# Altium schematic sheet tegprint.SchDoc
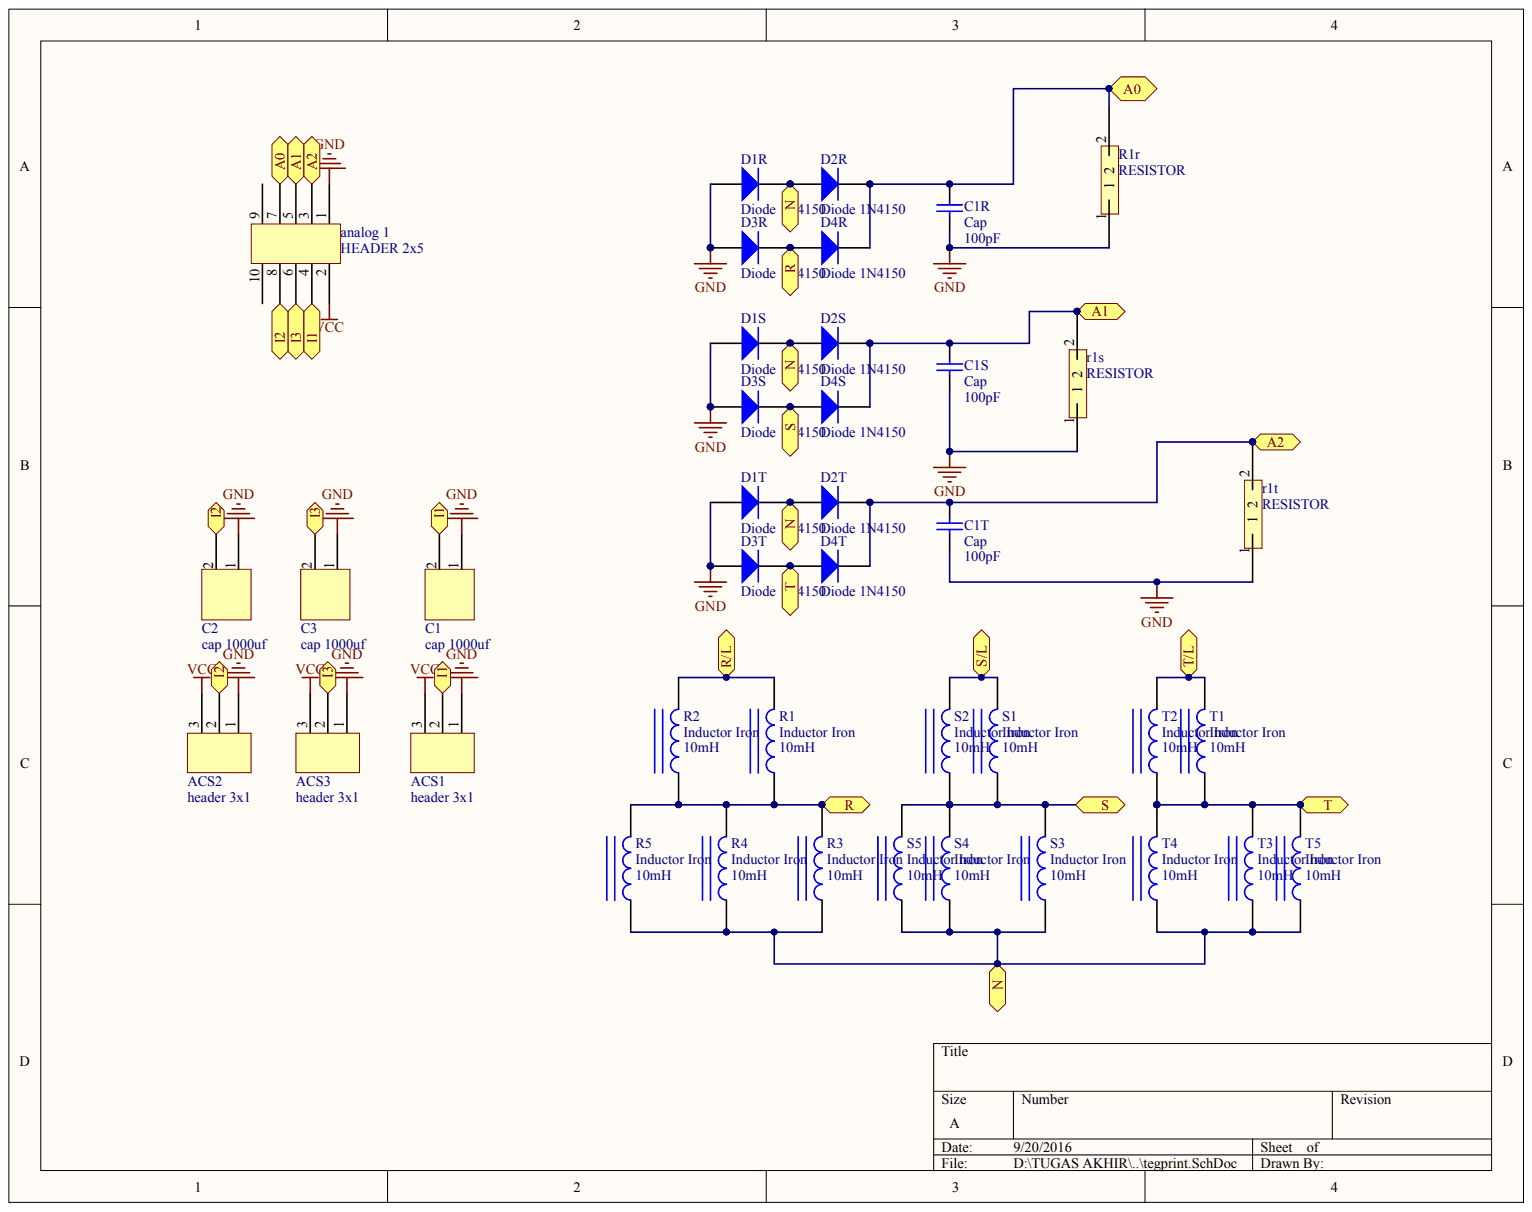
[diagram p0: tegprint.SchDoc]

## Parts
ACS1  header 3x1
ACS2  header 3x1
ACS3  header 3x1
analog_1  HEADER 2x5
C1  cap 1000uf
C1R  Cap  value 100pF
C1S  Cap  value 100pF
C1T  Cap  value 100pF
C2  cap 1000uf
C3  cap 1000uf
D1R  Diode 1N4150
D1S  Diode 1N4150
D1T  Diode 1N4150
D2R  Diode 1N4150
D2S  Diode 1N4150
D2T  Diode 1N4150
D3R  Diode 1N4150
D3S  Diode 1N4150
D3T  Diode 1N4150
D4R  Diode 1N4150
D4S  Diode 1N4150
D4T  Diode 1N4150
R1  Inductor Iron  value 10mH
R1r  RESISTOR
r1s  RESISTOR
r1t  RESISTOR
R2  Inductor Iron  value 10mH
R3  Inductor Iron  value 10mH
R4  Inductor Iron  value 10mH
R5  Inductor Iron  value 10mH
S1  Inductor Iron  value 10mH
S2  Inductor Iron  value 10mH
S3  Inductor Iron  value 10mH
S4  Inductor Iron  value 10mH
S5  Inductor Iron  value 10mH
T1  Inductor Iron  value 10mH
T2  Inductor Iron  value 10mH
T3  Inductor Iron  value 10mH
T4  Inductor Iron  value 10mH
T5  Inductor Iron  value 10mH

## Ports
A0, A1, A2, I1, I2, I3, N, R, R/L, S, S/L, T, T/L

## Nets
GND: ACS1.1 ACS2.1 ACS3.1 analog_1.1 C1.1 C1R.1 C1S.1 C1T.1 C2.1 C3.1 D1R.1 D1S.1 D1T.1 D3R.1 D3S.1 D3T.1 R1r.1 r1s.1 r1t.1
VCC: ACS1.3 ACS2.3 ACS3.3 analog_1.2
A0: analog_1.7 C1R.2 D2R.2 D4R.2 R1r.2 ; port A0
A1: analog_1.5 C1S.2 D2S.2 D4S.2 r1s.2 ; port A1
A2: analog_1.3 C1T.2 D2T.2 D4T.2 r1t.2 ; port A2
I1: ACS1.2 analog_1.4 C1.2 ; port I1
I2: ACS2.2 analog_1.8 C2.2 ; port I2
I3: ACS3.2 analog_1.6 C3.2 ; port I3
N: D1R.2 D1S.2 D1T.2 D2R.1 D2S.1 D2T.1 R3.1 R4.1 R5.1 S3.1 S4.1 S5.1 T3.1 T4.1 T5.1 ; port N
R: D3R.2 D4R.1 R1.1 R2.1 R3.2 R4.2 R5.2 ; port R
R/L: R1.2 R2.2 ; port R/L
S: D3S.2 D4S.1 S1.1 S2.1 S3.2 S4.2 S5.2 ; port S
S/L: S1.2 S2.2 ; port S/L
T: D3T.2 D4T.1 T1.1 T2.1 T3.2 T4.2 T5.2 ; port T
T/L: T1.2 T2.2 ; port T/L

Not connected: analog_1 9 10

## Library data of the parts
ACS1-ACS3 analog_1 C1 C1R C1S C1T C2 C3 D1R D1S D1T D2R D2S D2T D3R D3S D3T D4R D4S D4T R1 R1r r1s r1t R2-R5 S1-S5 T1-T5 = (no symbol name)
  C1R C1S C1T: Published: 8-Jun-2000; LatestRevisionDate: 17-Jul-2002; LatestRevisionNote: Re-released for DXP Platform.; PackageReference: RAD-0.3; PackageDescription: Radial Cap, Thru-Hole; 2 Leads; 0.3 in Pin Spacing
  D1R D1S D1T D2R D2S D2T D3R D3S D3T D4R D4S D4T: Published: 8-Jun-2000; LatestRevisionDate: 17-Jul-2002; LatestRevisionNote: Re-released for DXP Platform.; DatasheetVersion: 1997; PackageReference: DO-35; PackageDescription: Diode, Axial; Body 3.8 x 1.9 mm (LxDia. max), Lead Dia. 0.53 mm (max); Code_JEDEC: DO-204-AH; ComponentLink1Description: Manufacturer Link; ComponentLink1URL: http://www.fairchildsemi.com/
  R1-R5 S1-S5 T1-T5: Published: 24-Mar-1999; LatestRevisionDate: 17-Jul-2002; LatestRevisionNote: Re-released for DXP Platform.; PackageReference: AXIAL-0.9; PackageDescription: Axial Device, Thru-Hole; 2 Leads; 0.9 in Pin Spacing
  C1R C1S C1T D1R D1S D1T D2R D2S D2T D3R D3S D3T D4R D4S D4T R1-R5 S1-S5 T1-T5: Publisher: Altium Limited
